# Altium schematic sheet Volt.SchDoc
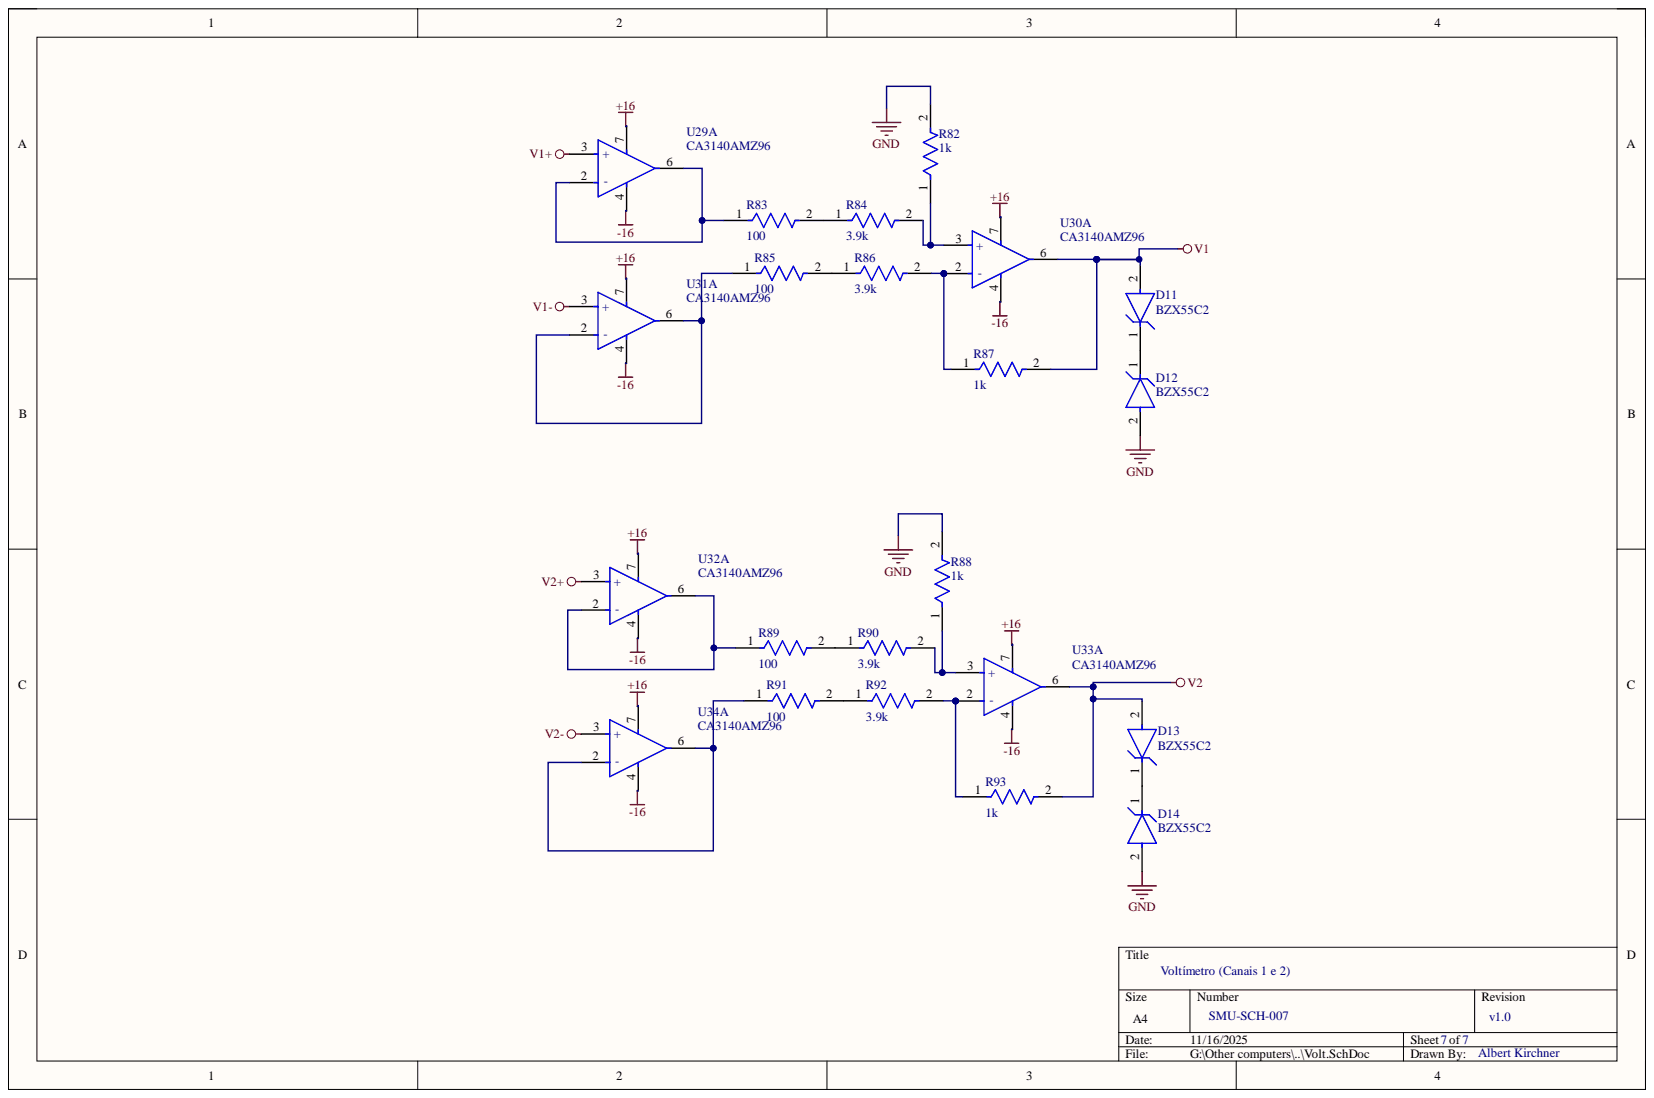
[diagram p0: Volt.SchDoc]

## Parts
D11  BZX55C2  [FP-SB-V-3906_04-031_4-MFG]
D12  BZX55C2  [FP-SB-V-3906_04-031_4-MFG]
D13  BZX55C2  [FP-SB-V-3906_04-031_4-MFG]
D14  BZX55C2  [FP-SB-V-3906_04-031_4-MFG]
R82  1k  [FP-CR1206-MFG]
R83  100  [FP-CR1206-MFG]
R84  3.9k  [FP-CR1206-MFG]
R85  100  [FP-CR1206-MFG]
R86  3.9k  [FP-CR1206-MFG]
R87  1k  [FP-CR1206-MFG]
R88  1k  [FP-CR1206-MFG]
R89  100  [FP-CR1206-MFG]
R90  3.9k  [FP-CR1206-MFG]
R91  100  [FP-CR1206-MFG]
R92  3.9k  [FP-CR1206-MFG]
R93  1k  [FP-CR1206-MFG]
U29  CA3140AMZ96  [M8_15_MFG]  (part A)
U30  CA3140AMZ96  [M8_15_MFG]  (part A)
U31  CA3140AMZ96  [M8_15_MFG]  (part A)
U32  CA3140AMZ96  [M8_15_MFG]  (part A)
U33  CA3140AMZ96  [M8_15_MFG]  (part A)
U34  CA3140AMZ96  [M8_15_MFG]  (part A)

## Nets
+16: U29.7 U30.7 U31.7 U32.7 U33.7 U34.7
-16: U29.4 U30.4 U31.4 U32.4 U33.4 U34.4
GND: D12.2 D14.2 R82.2 R88.2
V1: D11.2 R87.2 U30.6
V1+: U29.3(+)
V1-: U31.3(+)
V2: D13.2 R93.2 U33.6
V2+: U32.3(+)
V2-: U34.3(+)
NetD11_1: D11.1 D12.1
NetD13_1: D13.1 D14.1
NetR82_1: R82.1 R84.2 U30.3(+)
NetR83_1: R83.1 U29.2(-) U29.6
NetR83_2: R83.2 R84.1
NetR85_1: R85.1 U31.2(-) U31.6
NetR85_2: R85.2 R86.1
NetR86_2: R86.2 R87.1 U30.2(-)
NetR88_1: R88.1 R90.2 U33.3(+)
NetR89_1: R89.1 U32.2(-) U32.6
NetR89_2: R89.2 R90.1
NetR91_1: R91.1 U34.2(-) U34.6
NetR91_2: R91.2 R92.1
NetR92_2: R92.2 R93.1 U33.2(-)

## Library data of the parts
D11-D14 = CMP-02541-000018-1: DIODE ZENER 10V 500MW DO35; Breakdown Voltage: 10.6V; Element Configuration: Single; Impedance: 15R; Max Reverse Leakage Current: 100nA; Test Current: 5mA; Tolerance: 5%; Voltage Tolerance: 6%; Case/Package: DO-35; Component Kind: Standard; Lead Free: Lead Free; Library Name: Altium Content Vault; Max Operating Temperature: 175°C; Max Power Dissipation: 500mW; Min Operating Temperature: -65°C; Mount: Through Hole; Number of Pins: 2; Package Quantity: 30000; Packaging: Tape and Reel; Power Dissipation: 500mW; Radiation Hardening: No; RoHS Compliant: Yes; Series: Automotive, AEC-Q101; Zener Voltage: 10V
R82-R93 = CMP-07231-003158-1: Thick Film Chip Resistors 1206 330Ω 0.25W 1% 100ppm/°C; Max Power Dissipation: 250mW; Resistance: 330R; Voltage Rating: 200V; Case Code (Imperial): 1206; Case Code (Metric): 3216; Case/Package: 1206; Component Kind: Standard; Composition: Thick Film; Contact Plating: Tin; Depth: 1.6mm; Height: 0.6mm; Length: 3.2mm; Library Name: Altium Content Vault; Max Operating Temperature: 155°C; Military Standard: Not; Min Operating Temperature: -55°C; Mount: Surface Mount; Number of Pins: 2; Number of Terminations: 2; Package Quantity: 5000; Packaging: Tape and Reel; Power Rating: 250mW; Radiation Hardening: No; REACH SVHC: No SVHC; Temperature Coefficient: 100ppm/°C; Termination: SMD/SMT; Thickness: 610um; Tolerance: 1%; Voltage Rating (DC): 200V; Width: 1.6mm
U29-U34 = CMP-00572-88253531-2: CA3140 Series 36 V 4.5 MHz BiMOS Operational Amplifier - SOIC-8; Manufacturer Lifecycle Status: ACTIVE; Bandwidth: 4.5MHz; Common Mode Rejection Ratio: 90dB; Dual Supply Voltage: 9V; Gain Bandwidth Product: 3.7MHz; Input Bias Current: 10pA; Input Offset Voltage (Vos): 2mV; Max Dual Supply Voltage: 18V; Max Supply Voltage: 36V; Min Dual Supply Voltage: 2V; Min Supply Voltage: 4V; Nominal Supply Current: 1.6mA; Number of Channels: 1; Operating Supply Current: 4mA; Operating Supply Voltage: 28V; Output Current: 10mA; Output Current per Channel: 40mA; Pitch: 1.3mm; Power Dissipation: 180mW; Power Supply Rejection Ratio (PSRR): 80dB; RAM Size: 640kB; Slew Rate: 9V/µs; Voltage Gain: 100dB; Case/Package: SOIC; Component Kind: Standard; ComponentLink1Description: Manufacturer's Website; ComponentLink1URL: http://www.renesas.com; ComponentLink2Description: Datasheet URL; ComponentLink2URL: https://octopart.com/cad-content/api/get-model-datasheet/15189/88253531; ComponentLink3Description: Manufacturer's Package Drawing; ComponentLink3URL: https://www.renesas.com/en/document/psc/m815-8-lead-narrow-body-small-outline-plastic-package; Contact Plating: Tin; Height: 0m; HelpURL: https://octopart.com/cad-content/api/get-model-datasheet/15189/88253531; Lead Free: Lead Free; Length: 4.9mm; Library Name: Altium Content Vault; Lifecycle Status: Production; Max Operating Temperature: 85°C; Min Operating Temperature: -40°C; Mount: Surface Mount; Number of Circuits: 1; Number of Pins: 8; Radiation Hardening: No; RoHS: Compliant; Schedule B: 8542330000; Width: 3.9mm
